# Altium schematic sheet Extra6_UI.SchDoc
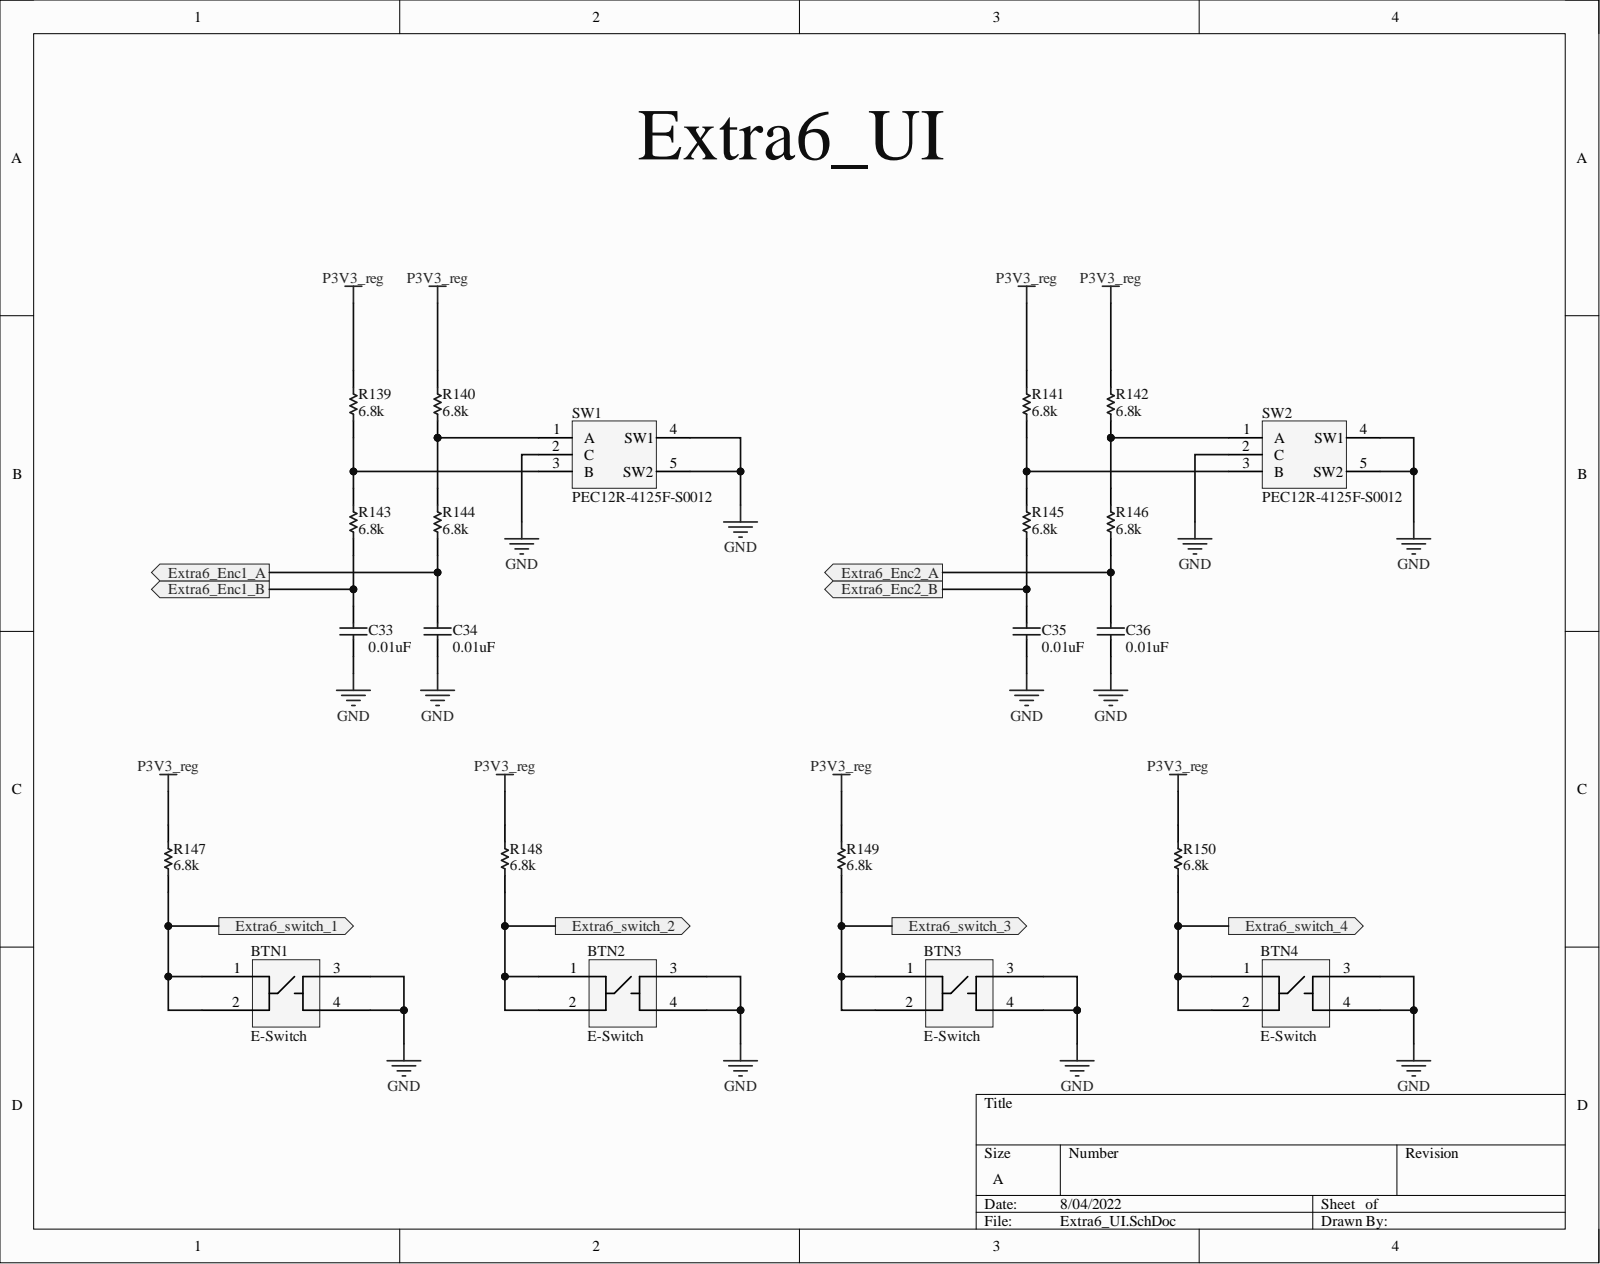
[diagram p0: Extra6_UI.SchDoc]

## Parts
BTN1  E-Switch  [pushbutton]
BTN2  E-Switch  [pushbutton]
BTN3  E-Switch  [pushbutton]
BTN4  E-Switch  [pushbutton]
C33  0.01uF  [PCB-vcjr8nxpwfuh09uwlcd6-1]
C34  0.01uF  [PCB-vcjr8nxpwfuh09uwlcd6-1]
C35  0.01uF  [PCB-vcjr8nxpwfuh09uwlcd6-1]
C36  0.01uF  [PCB-vcjr8nxpwfuh09uwlcd6-1]
R139  6.8k  [RESC2013X55X40NL15T20]
R140  6.8k  [RESC2013X55X40NL15T20]
R141  6.8k  [RESC2013X55X40NL15T20]
R142  6.8k  [RESC2013X55X40NL15T20]
R143  6.8k  [RESC2013X55X40NL15T20]
R144  6.8k  [RESC2013X55X40NL15T20]
R145  6.8k  [RESC2013X55X40NL15T20]
R146  6.8k  [RESC2013X55X40NL15T20]
R147  6.8k  [RESC2013X55X40NL15T20]
R148  6.8k  [RESC2013X55X40NL15T20]
R149  6.8k  [RESC2013X55X40NL15T20]
R150  6.8k  [RESC2013X55X40NL15T20]
SW1  PEC12R-4125F-S0012  [PCB-p946zemvewwgv2vn527p-1]
SW2  PEC12R-4125F-S0012  [PCB-p946zemvewwgv2vn527p-1]

## Ports
Extra6_Enc1_A, Extra6_Enc1_B, Extra6_Enc2_A, Extra6_Enc2_B, Extra6_switch_1, Extra6_switch_2, Extra6_switch_3, Extra6_switch_4

## Nets
GND: BTN1.3 BTN1.4 BTN2.3 BTN2.4 BTN3.3 BTN3.4 BTN4.3 BTN4.4 C33.1 C34.1 C35.1 C36.1 SW1.2(C) SW1.4 SW1.5(SW2) SW2.2(C) SW2.4(SW1) SW2.5
P3V3_reg: R139.2 R140.2 R141.2 R142.2 R147.2 R148.2 R149.2 R150.2
Extra6_Enc1_A: C34.2 R144.1 ; port Extra6_Enc1_A
Extra6_Enc1_B: C33.2 R143.1 ; port Extra6_Enc1_B
Extra6_Enc2_A: C36.2 R146.1 ; port Extra6_Enc2_A
Extra6_Enc2_B: C35.2 R145.1 ; port Extra6_Enc2_B
Extra6_switch_1: BTN1.1 BTN1.2 R147.1 ; port Extra6_switch_1
Extra6_switch_2: BTN2.1 BTN2.2 R148.1 ; port Extra6_switch_2
Extra6_switch_3: BTN3.1 BTN3.2 R149.1 ; port Extra6_switch_3
Extra6_switch_4: BTN4.1 BTN4.2 R150.1 ; port Extra6_switch_4
NetR139_1: R139.1 R143.2 SW1.3(B)
NetR140_1: R140.1 R144.2 SW1.1(A)
NetR141_1: R141.1 R145.2 SW2.3(B)
NetR142_1: R142.1 R146.2 SW2.1(A)

## Library data of the parts
BTN1-BTN4 = CMP-1507dc1d1294cac0-1: TL3301 Series SPST 1.6 N Round Button Gull Wing Surface Mount Tactile Switch; Manufacturer Part Number: TL3301NF160QG; Actuator Type: Standard; Contact Current Rating: 0.05 A; Contacts Type: SPST-NO; Lead-Free Status: Lead Free; Supply Voltage (DC): 12.0 V; Component Kind: Standard; Contact Plating: Silver; Library Name: My Personal Space; Mounting Style: Surface Mount; Octopart URL: http://octopart.com/tl3301nf160qg-e-switch-1057525; OctopartID: 1507dc1d1294cac0; Orientation: Vertical; Packaging: Reel; RoHS: Compliant; Size-Length: 0.006 m; Size-Width: 0.006 m
C33-C36 = CMP-526e9b1a13078da9-3: CL21 Series 10 nF 50V ±10 % Tolerance X7R SMT Multilayer Ceramic Capacitor; Manufacturer Part Number: CL21B103KBANNNC; Capacitance: 1e-08 F; Capacitance Tolerance: ±10%; Lead-Free Status: Lead Free; Voltage Rating (DC): 50.0 V; Case/Package: 0805; Component Kind: Standard; Dielectric Characteristic: X7R; Library Name: My Personal Space; Mounting Style: Surface Mount; Octopart URL: http://octopart.com/cl21b103kbannnc-samsung-8283151; OctopartID: 526e9b1a13078da9; RoHS: Compliant
R139 = CMP-1953953-15: RES SMD 6.8K OHM 0.1% 1/8W 0805; Manufacturer Part Number: TNPW080510K0BEEN; Case/Package (SI): 2012; Lead-Free Status: Lead Free; Power Rating: 0.125 W; ReplaceComponentId: THVNXYHF; Resistance: 6800.0 Ω; Temperature Coefficient: 25.0 ppm/°C; Voltage Rating (DC): 150.0 V; Case/Package: 0805; Component Kind: Standard; Library Name: My Personal Space; Number of Pins: 2; OctopartID: 2ed9e58acfb9f11e; Packaging: Tape & Reel (TR); PartId: 1; Resistance Tolerance: ±0.1%; RoHS: Compliant
R140 = CMP-1953953-15: RES SMD 6.8K OHM 0.1% 1/8W 0805; Manufacturer Part Number: TNPW080510K0BEEN; Case/Package (SI): 2012; Lead-Free Status: Lead Free; Power Rating: 0.125 W; ReplaceComponentId: VLANIHJE; Resistance: 6800.0 Ω; Temperature Coefficient: 25.0 ppm/°C; Voltage Rating (DC): 150.0 V; Case/Package: 0805; Component Kind: Standard; Library Name: My Personal Space; Number of Pins: 2; OctopartID: 2ed9e58acfb9f11e; Packaging: Tape & Reel (TR); PartId: 1; Resistance Tolerance: ±0.1%; RoHS: Compliant
R141 = CMP-1953953-15: RES SMD 6.8K OHM 0.1% 1/8W 0805; Manufacturer Part Number: TNPW080510K0BEEN; Case/Package (SI): 2012; Lead-Free Status: Lead Free; Power Rating: 0.125 W; ReplaceComponentId: XTSGKBTV; Resistance: 6800.0 Ω; Temperature Coefficient: 25.0 ppm/°C; Voltage Rating (DC): 150.0 V; Case/Package: 0805; Component Kind: Standard; Library Name: My Personal Space; Number of Pins: 2; OctopartID: 2ed9e58acfb9f11e; Packaging: Tape & Reel (TR); PartId: 1; Resistance Tolerance: ±0.1%; RoHS: Compliant
R142 = CMP-1953953-15: RES SMD 6.8K OHM 0.1% 1/8W 0805; Manufacturer Part Number: TNPW080510K0BEEN; Case/Package (SI): 2012; Lead-Free Status: Lead Free; Power Rating: 0.125 W; ReplaceComponentId: DFEOGHDO; Resistance: 6800.0 Ω; Temperature Coefficient: 25.0 ppm/°C; Voltage Rating (DC): 150.0 V; Case/Package: 0805; Component Kind: Standard; Library Name: My Personal Space; Number of Pins: 2; OctopartID: 2ed9e58acfb9f11e; Packaging: Tape & Reel (TR); PartId: 1; Resistance Tolerance: ±0.1%; RoHS: Compliant
R143 = CMP-1953953-15: RES SMD 6.8K OHM 0.1% 1/8W 0805; Manufacturer Part Number: TNPW080510K0BEEN; Case/Package (SI): 2012; Lead-Free Status: Lead Free; Power Rating: 0.125 W; ReplaceComponentId: WRUSHHTN; Resistance: 6800.0 Ω; Temperature Coefficient: 25.0 ppm/°C; Voltage Rating (DC): 150.0 V; Case/Package: 0805; Component Kind: Standard; Library Name: My Personal Space; Number of Pins: 2; OctopartID: 2ed9e58acfb9f11e; Packaging: Tape & Reel (TR); PartId: 1; Resistance Tolerance: ±0.1%; RoHS: Compliant
R144 = CMP-1953953-15: RES SMD 6.8K OHM 0.1% 1/8W 0805; Manufacturer Part Number: TNPW080510K0BEEN; Case/Package (SI): 2012; Lead-Free Status: Lead Free; Power Rating: 0.125 W; ReplaceComponentId: SYCSDQTI; Resistance: 6800.0 Ω; Temperature Coefficient: 25.0 ppm/°C; Voltage Rating (DC): 150.0 V; Case/Package: 0805; Component Kind: Standard; Library Name: My Personal Space; Number of Pins: 2; OctopartID: 2ed9e58acfb9f11e; Packaging: Tape & Reel (TR); PartId: 1; Resistance Tolerance: ±0.1%; RoHS: Compliant
R145 = CMP-1953953-15: RES SMD 6.8K OHM 0.1% 1/8W 0805; Manufacturer Part Number: TNPW080510K0BEEN; Case/Package (SI): 2012; Lead-Free Status: Lead Free; Power Rating: 0.125 W; ReplaceComponentId: MHXZUJWW; Resistance: 6800.0 Ω; Temperature Coefficient: 25.0 ppm/°C; Voltage Rating (DC): 150.0 V; Case/Package: 0805; Component Kind: Standard; Library Name: My Personal Space; Number of Pins: 2; OctopartID: 2ed9e58acfb9f11e; Packaging: Tape & Reel (TR); PartId: 1; Resistance Tolerance: ±0.1%; RoHS: Compliant
R146 = CMP-1953953-15: RES SMD 6.8K OHM 0.1% 1/8W 0805; Manufacturer Part Number: TNPW080510K0BEEN; Case/Package (SI): 2012; Lead-Free Status: Lead Free; Power Rating: 0.125 W; ReplaceComponentId: PHLLFVSV; Resistance: 6800.0 Ω; Temperature Coefficient: 25.0 ppm/°C; Voltage Rating (DC): 150.0 V; Case/Package: 0805; Component Kind: Standard; Library Name: My Personal Space; Number of Pins: 2; OctopartID: 2ed9e58acfb9f11e; Packaging: Tape & Reel (TR); PartId: 1; Resistance Tolerance: ±0.1%; RoHS: Compliant
R147 = CMP-1953953-15: RES SMD 6.8K OHM 0.1% 1/8W 0805; Manufacturer Part Number: TNPW080510K0BEEN; Case/Package (SI): 2012; Lead-Free Status: Lead Free; Power Rating: 0.125 W; ReplaceComponentId: EEIBFOZQ; Resistance: 6800.0 Ω; Temperature Coefficient: 25.0 ppm/°C; Voltage Rating (DC): 150.0 V; Case/Package: 0805; Component Kind: Standard; Library Name: My Personal Space; Number of Pins: 2; OctopartID: 2ed9e58acfb9f11e; Packaging: Tape & Reel (TR); PartId: 1; Resistance Tolerance: ±0.1%; RoHS: Compliant
R148 = CMP-1953953-15: RES SMD 6.8K OHM 0.1% 1/8W 0805; Manufacturer Part Number: TNPW080510K0BEEN; Case/Package (SI): 2012; Lead-Free Status: Lead Free; Power Rating: 0.125 W; ReplaceComponentId: KPLTKMDL; Resistance: 6800.0 Ω; Temperature Coefficient: 25.0 ppm/°C; Voltage Rating (DC): 150.0 V; Case/Package: 0805; Component Kind: Standard; Library Name: My Personal Space; Number of Pins: 2; OctopartID: 2ed9e58acfb9f11e; Packaging: Tape & Reel (TR); PartId: 1; Resistance Tolerance: ±0.1%; RoHS: Compliant
R149 = CMP-1953953-15: RES SMD 6.8K OHM 0.1% 1/8W 0805; Manufacturer Part Number: TNPW080510K0BEEN; Case/Package (SI): 2012; Lead-Free Status: Lead Free; Power Rating: 0.125 W; ReplaceComponentId: TIAKROSK; Resistance: 6800.0 Ω; Temperature Coefficient: 25.0 ppm/°C; Voltage Rating (DC): 150.0 V; Case/Package: 0805; Component Kind: Standard; Library Name: My Personal Space; Number of Pins: 2; OctopartID: 2ed9e58acfb9f11e; Packaging: Tape & Reel (TR); PartId: 1; Resistance Tolerance: ±0.1%; RoHS: Compliant
R150 = CMP-1953953-15: RES SMD 6.8K OHM 0.1% 1/8W 0805; Manufacturer Part Number: TNPW080510K0BEEN; Case/Package (SI): 2012; Lead-Free Status: Lead Free; Power Rating: 0.125 W; ReplaceComponentId: GWKHGRMR; Resistance: 6800.0 Ω; Temperature Coefficient: 25.0 ppm/°C; Voltage Rating (DC): 150.0 V; Case/Package: 0805; Component Kind: Standard; Library Name: My Personal Space; Number of Pins: 2; OctopartID: 2ed9e58acfb9f11e; Packaging: Tape & Reel (TR); PartId: 1; Resistance Tolerance: ±0.1%; RoHS: Compliant
SW1 SW2 = CMP-93f75b6b29b84c30-8: 12 mm Incremental Encoder 2-Bit Quadrature 5-Pin; Notes: Switch pins missing from 3D model; Number of Detents: 12; Component Kind: Standard; Library Name: My Personal Space; Manufacturer Part Number: PEC12R-4125F-S0012; Mounting Style: Through Hole, Snap-In; Octopart URL: http://octopart.com/pec12r-4125f-s0012-bourns-26648361; OctopartID: 93f75b6b29b84c30; Packaging: Bulk; RoHS: Compliant; Size-Height: 13.4 mm; Size-Length: 13.4 mm; Size-Width: 12.5 mm
